# Altium schematic sheet Sch-QWA17_RTC.SchDoc(Sch-QWA17_RTC)
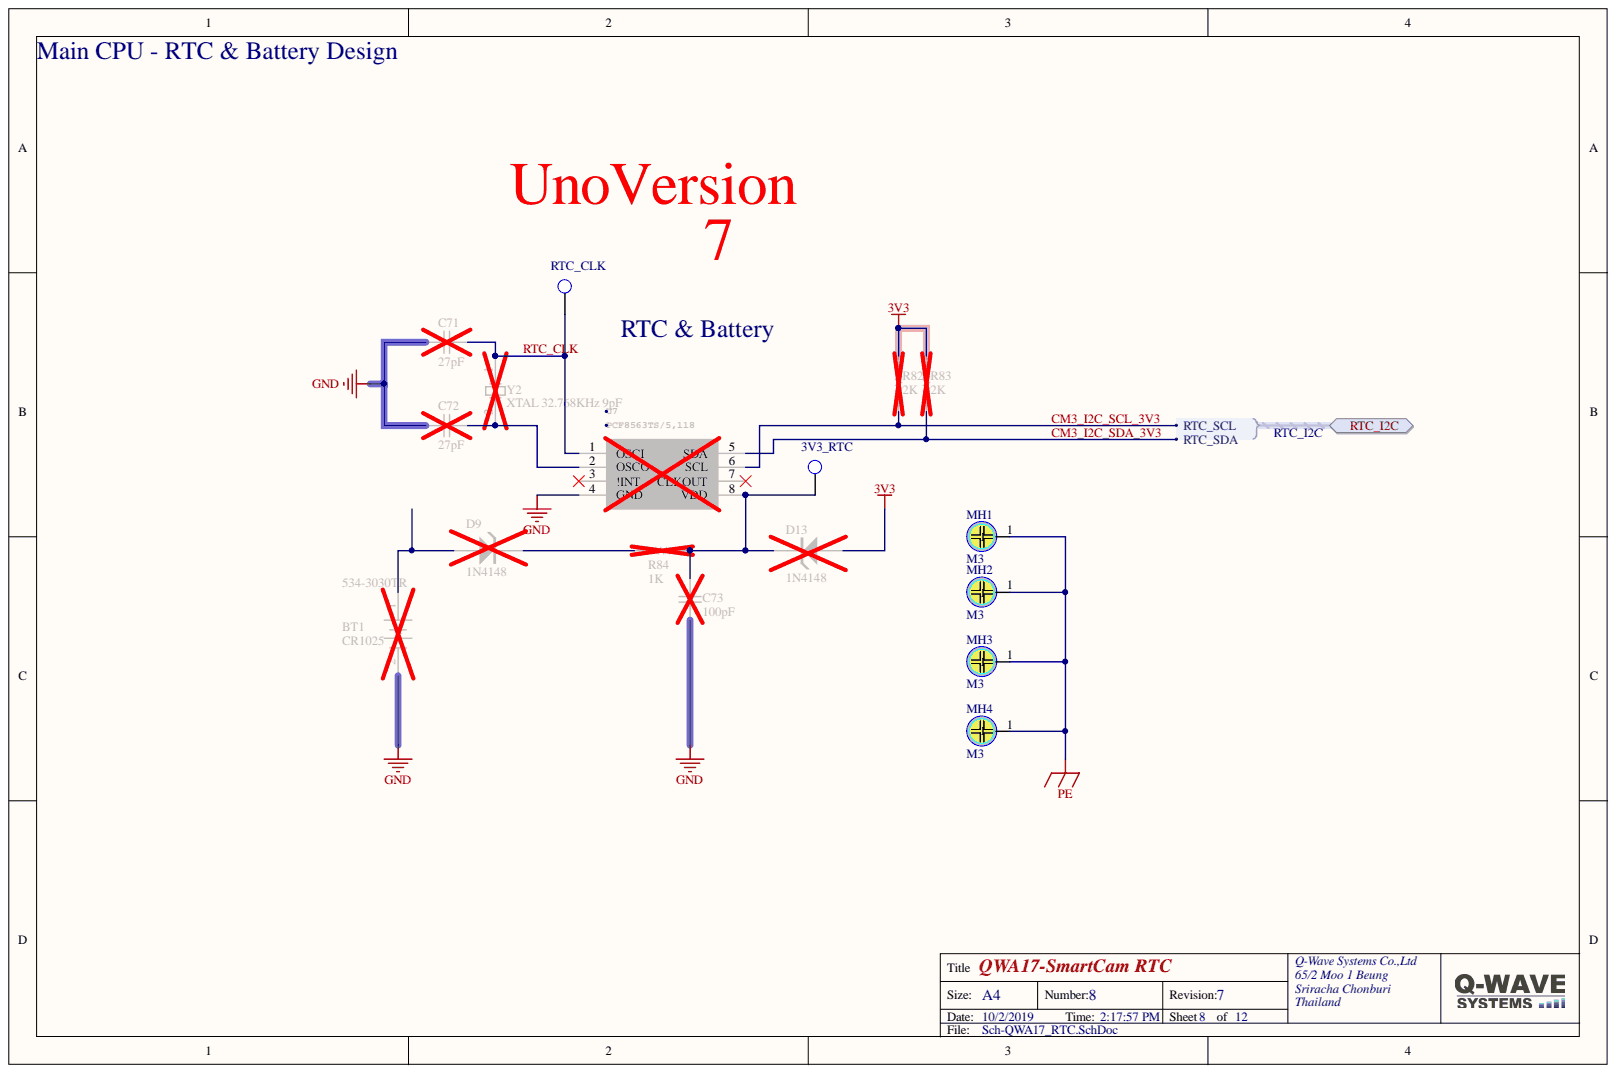
[diagram p0: Sch-QWA17_RTC.SchDoc]

## Parts
3V3_RTC  3V3_RTC  [TEST_POINT]
BT1  CR1025  Supplier Part Number 1: 534-3030TR  [3030TR]
C71  27pF  [CAP_0603]
C72  27pF  [CAP_0603]
C73  100pF  [CAP_0603]
D9  1N4148  [sod-123]
D13  1N4148  [sod-123]
MH1  M3  [MH3-4]
MH2  M3  [MH3-4]
MH3  M3  [MH3-4]
MH4  M3  [MH3-4]
R82  2K  [RES_0603]
R83  2K  [RES_0603]
R84  1K  [RES_0603]
RTC_CLK  RTC_CLK  [TEST_POINT]
U7  PCF8563TS/5,118  [SOT65P490X110-8N]
Y2  XTAL 32.768KHz 9pF  [XT_3215_2]

## Ports
RTC_I2C, RTC_I2C.RTC_SCL, RTC_I2C.RTC_SDA

## Nets
3V3: D13.A R82.2 R83.2
GND: BT1.2 C71.2 C72.2 C73.2 U7.4(GND)
PE: MH1.1 MH2.1 MH3.1 MH4.1
CM3_I2C_SCL_3V3: R82.1 U7.6(SCL) ; port RTC_I2C
CM3_I2C_SDA_3V3: R83.1 U7.5(SDA) ; port RTC_I2C
RTC_CLK: C71.1 RTC_CLK.1 U7.1(OSCI) Y2.1
Net3V3_RTC_1: 3V3_RTC.1 C73.1 D13.K R84.1 U7.8(VDD)
NetBT1_1: BT1.1 D9.A
NetC72_1: C72.1 U7.2(OSCO) Y2.2
NetD9_K: D9.K R84.2

Not connected: U7 3(!INT) 7(CLKOUT)

## Library data of the parts
3V3_RTC RTC_CLK = TEST_POINT: Test Point; Component Kind: Standard; Library Name: Misc.SCHLIB
BT1 = Battery: Coin Cell Battery Holders SM COIN CELL BH 10mm; MFG: Keystone; Component Kind: Standard; Library Name: Miscellaneous Devices.IntLib; Supplier 1: Mouser; Supplier1: Mouser; Supplier1 Price: 0.64; Supplier_Part_Number: 534-3000
C71 C72 = Cap Semi: 27pF ±5% 50V Ceramic Capacitor C0G, NP0 0603; MFG: KEMET; Component Kind: Standard; Library Name: Miscellaneous Devices.IntLib; Simulation: CAP
C73 = Cap Semi: 100pF ±5% 50V Ceramic Capacitor C0G, NP0 0603; MFG: KEMET; MFG P/N: C0603C101J5GACTU; Component Kind: Standard; Library Name: Miscellaneous Devices.IntLib; Simulation: CAP
D9 D13 = BZT52C5V6: DIODE / DIODE-B; Component Kind: Standard; Library Name: vh_altium_library.intLib; Supplier 1: Digi-Key; Supplier Part Number 1: BZT52C5V6-FDITR-ND
MH1-MH4 = HOLD: Component Kind: Standard; Library Name: Mechanical.SchLib
R82-R84 = Res Semi: Resistor 0603; Component Kind: Standard; Simulation: RES
  R82 R83: Library Name: SCH_Passive.SchLib
  R84: Library Name: Miscellaneous Devices.IntLib
U7 = PCF8563TS_5,118: REAL TIME CLOCK, 5.5V, I2C, TSSOP-8; Manufacturer_Name: NXP; GateName_1: G$1; Component Kind: Standard; DeviceSetName: PCF8563TS_5,118; Height: 1.1mm; Library Name: PCF8563TS_5_118.IntLib; LibraryName: PCF8563TS_5_118; Manufacturer_Part_Number: PCF8563TS/5,118; Mouser_Part_Number: 771-PCF8563TS/5118; SymbolName_1: PCF8563TS_5,118
Y2 = XTAL: Crystal Unit 32.768KHz ±20ppm, 9pF; ES P/N: 0833-0016-9; MFG: NDK; MFG P/N: NX3215SA-32.768K-STD-MUA-9; Component Kind: Standard; ES Link: https://www.es.co.th/detail.asp?Prod=083300169; Library Name: Miscellaneous Devices.IntLib; Supplier1: ES
